# Altium schematic sheet MSXIV_BatteryModuleConnectorBoard.SchDoc
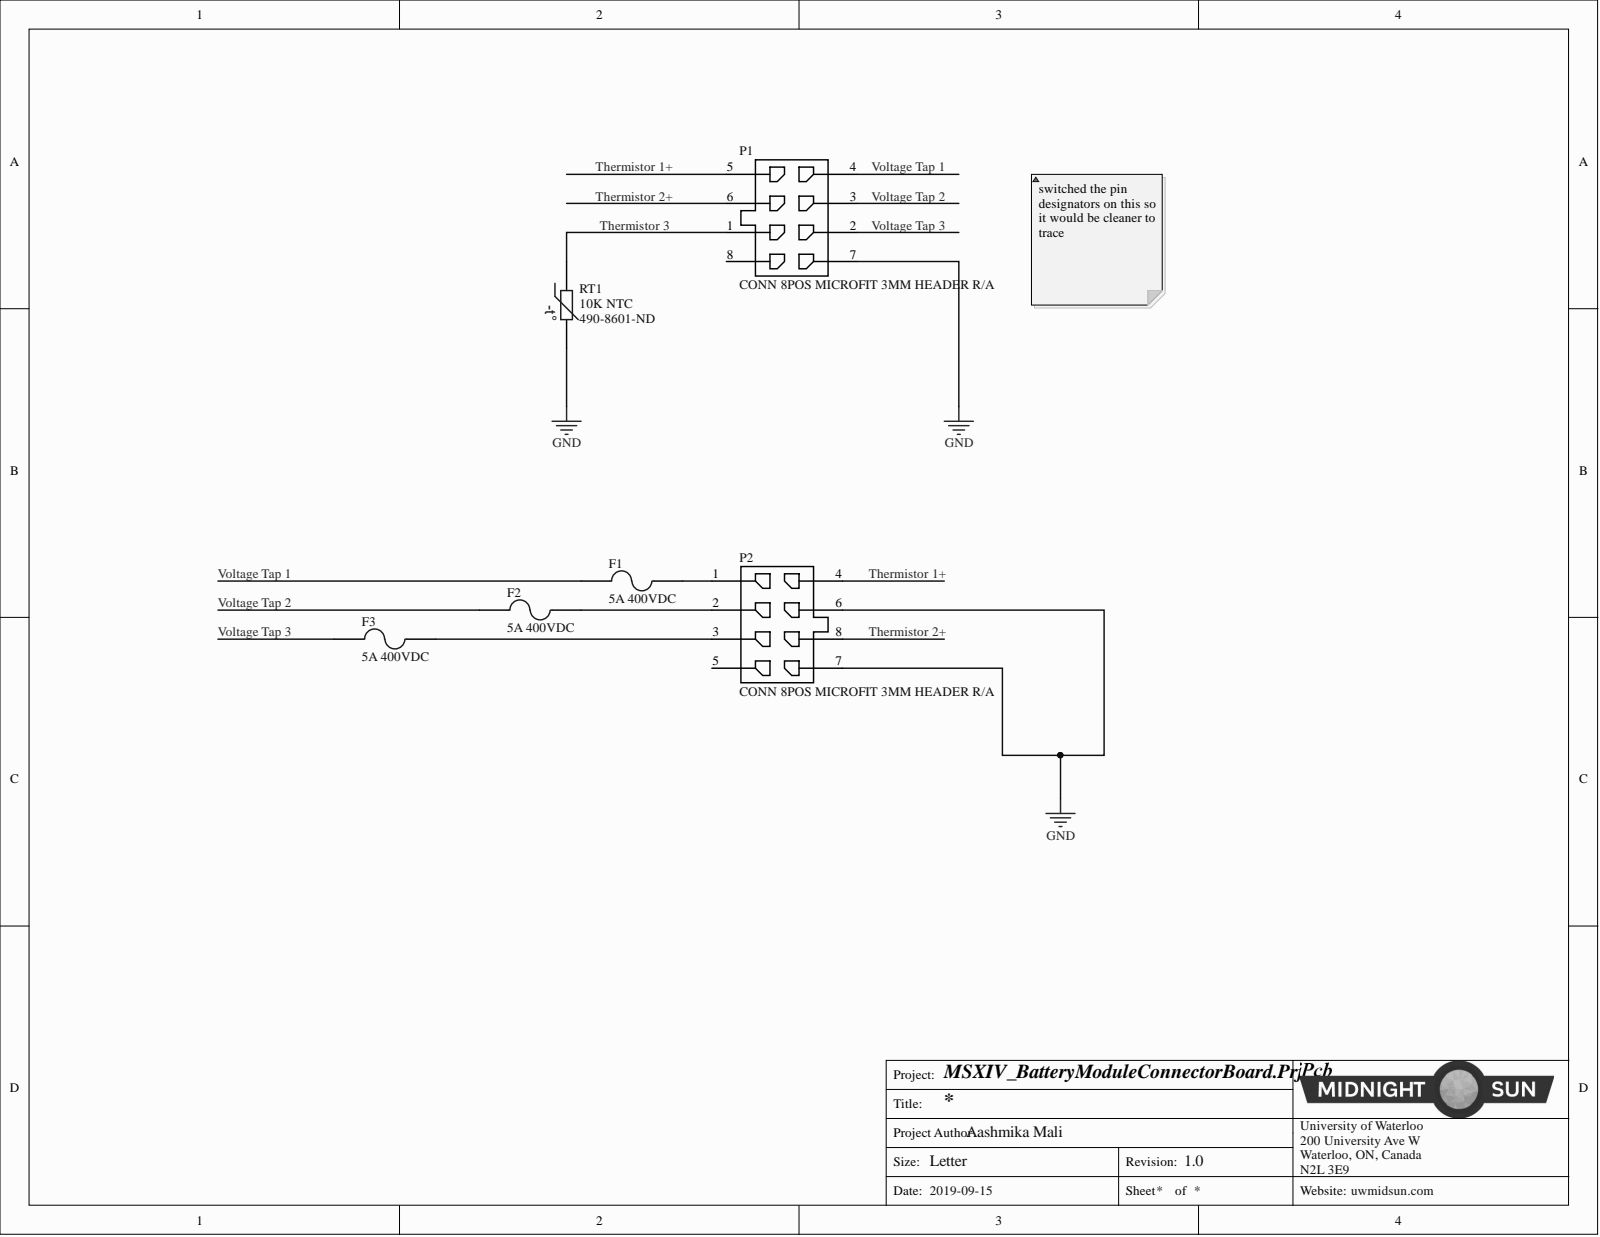
[diagram p0: MSXIV_BatteryModuleConnectorBoard.SchDoc]

## Parts
F1  5A 400VDC  [FUSE 5A 400VDC RADIAL]
F2  5A 400VDC  [FUSE 5A 400VDC RADIAL]
F3  5A 400VDC  [FUSE 5A 400VDC RADIAL]
P1  CONN 8POS MICROFIT 3MM HEADER R/A  [CONN, 8POS R/A MICRO-FIT]
P2  CONN 8POS MICROFIT 3MM HEADER R/A  [CONN, 8POS R/A MICRO-FIT]
RT1  10K NTC  Supplier Part Number 1: 490-8601-ND  [NTC THERMISTOR BEAD]

## Nets
GND: P1.7 P2.6 P2.7 RT1.2
Thermistor 1+: P1.5 P2.4
Thermistor 2+: P1.6 P2.8
Thermistor 3: P1.1 RT1.1
Voltage Tap 1: F1.1 P1.4
Voltage Tap 2: F2.1 P1.3
Voltage Tap 3: F3.1 P1.2
NetF1_2: F1.2 P2.1
NetF2_2: F2.2 P2.2
NetF3_2: F3.2 P2.3

Not connected: P1 8; P2 5

## Library data of the parts
F1-F3 = FUSE 5A 125VAC/400VDC RADIAL: FUSE BOARD MNT 5A 125VAC/400VDC; Manufacturer: Eaton; Manufacturer Part Number: BK/PCE-5-R; Approvals: CSA, UL; Breaking Capacity @ Rated Voltage: 10kA AC, 4.2kA DC; Fuse Type: Board Mount (Cartridge Style Excluded); Response Time: Fast; Category: Circuit Protection; Color: -; Component Kind: Standard; ComponentLink1Description: http://www.cooperindustries.com/content/dam/public/bussmann/Electronics/Resources/product-datasheets/Bus_Elx_DS_2034_PC_Tron_Series.pdf; ComponentLink1URL: http://www.cooperindustries.com/content/dam/public/bussmann/Electronics/Resources/product-datasheets/Bus_Elx_DS_2034_PC_Tron_Series.pdf; Mounting Type: Through Hole; Operating Temperature: -; Package / Case: Radial, Box; Packaging: Bulk; Part Status: Active; Pricing 1: 1=3.06, 5=2.91, 10=2.765, 50=2.2306, 100=1.8911, 250=1.8426, 500=1.55166, 1000=1.38196, 5000=1.21224 (CAD); RoHS: RoHS Compliant; Size / Dimension: 0.300" L x 0.095" W x 0.170" H (7.62mm x 2.41mm x 4.32mm); Stock 1: 0; Supplier 1: Digi-Key; Supplier Part Number 1: 283-2770-ND; Library Name: Schematic Diagrams.SchLib
P1 P2 = CONN 8POS MICROFIT 3MM HEADER R/A : Connector Header Through Hole, Right Angle 8 position 0.118" (3.00mm); Manufacturer 1: Molex; Manufacturer Part Number 1: 0430450800; Current Rating (Amps) : 8A; Number of Positions : 8; Voltage Rating : 600V; Component Kind: Standard; Contact Type : Male Pin; Number of Rows : 2; Row Spacing - Mating : 0.118" (3.00mm); Supplier 1: Digi-Key; Supplier Part Number 1: WM1816-ND; Library Name: Schematic Diagrams.SchLib
RT1 = NTC THERMISTOR 10K 1% BEAD: NTC Thermistor 10k Bead; Manufacturer: Murata Electronics North America; Manufacturer Part Number: NXRT15XH103FA1B030; B25/50: 3380K; B25/85: 3434K; B25/100: 3455K; B Value Tolerance: ±1%; B0/50: -; B25/75: -; Category: Sensors, Transducers; Component Kind: Standard; ComponentLink1Description: https://www.murata.com/~/media/webrenewal/support/library/catalog/products/thermistor/ntc/r44e.ashx; ComponentLink1URL: https://www.murata.com/~/media/webrenewal/support/library/catalog/products/thermistor/ntc/r44e.ashx; Length - Lead Wire: -; Mounting Type: Through Hole; Operating Temperature: -40°C ~ 125°C; Package / Case: Bead; Packaging: Bulk; Part Status: Active; Pricing 1: 1=0.96, 5=0.91, 10=0.792, 25=0.696, 50=0.5784, 100=0.4925, 500=0.42834, 1000=0.3748, 5000=0.33197 (CAD); Resistance in Ohms @ 25°C: 10k; Resistance Tolerance: ±1%; RoHS: RoHS Compliant; Stock 1: 25017; Supplier 1: Digi-Key; Library Name: Schematic Diagrams.SchLib
